# Altium schematic sheet lv_comm.SchDoc(lv_comm)
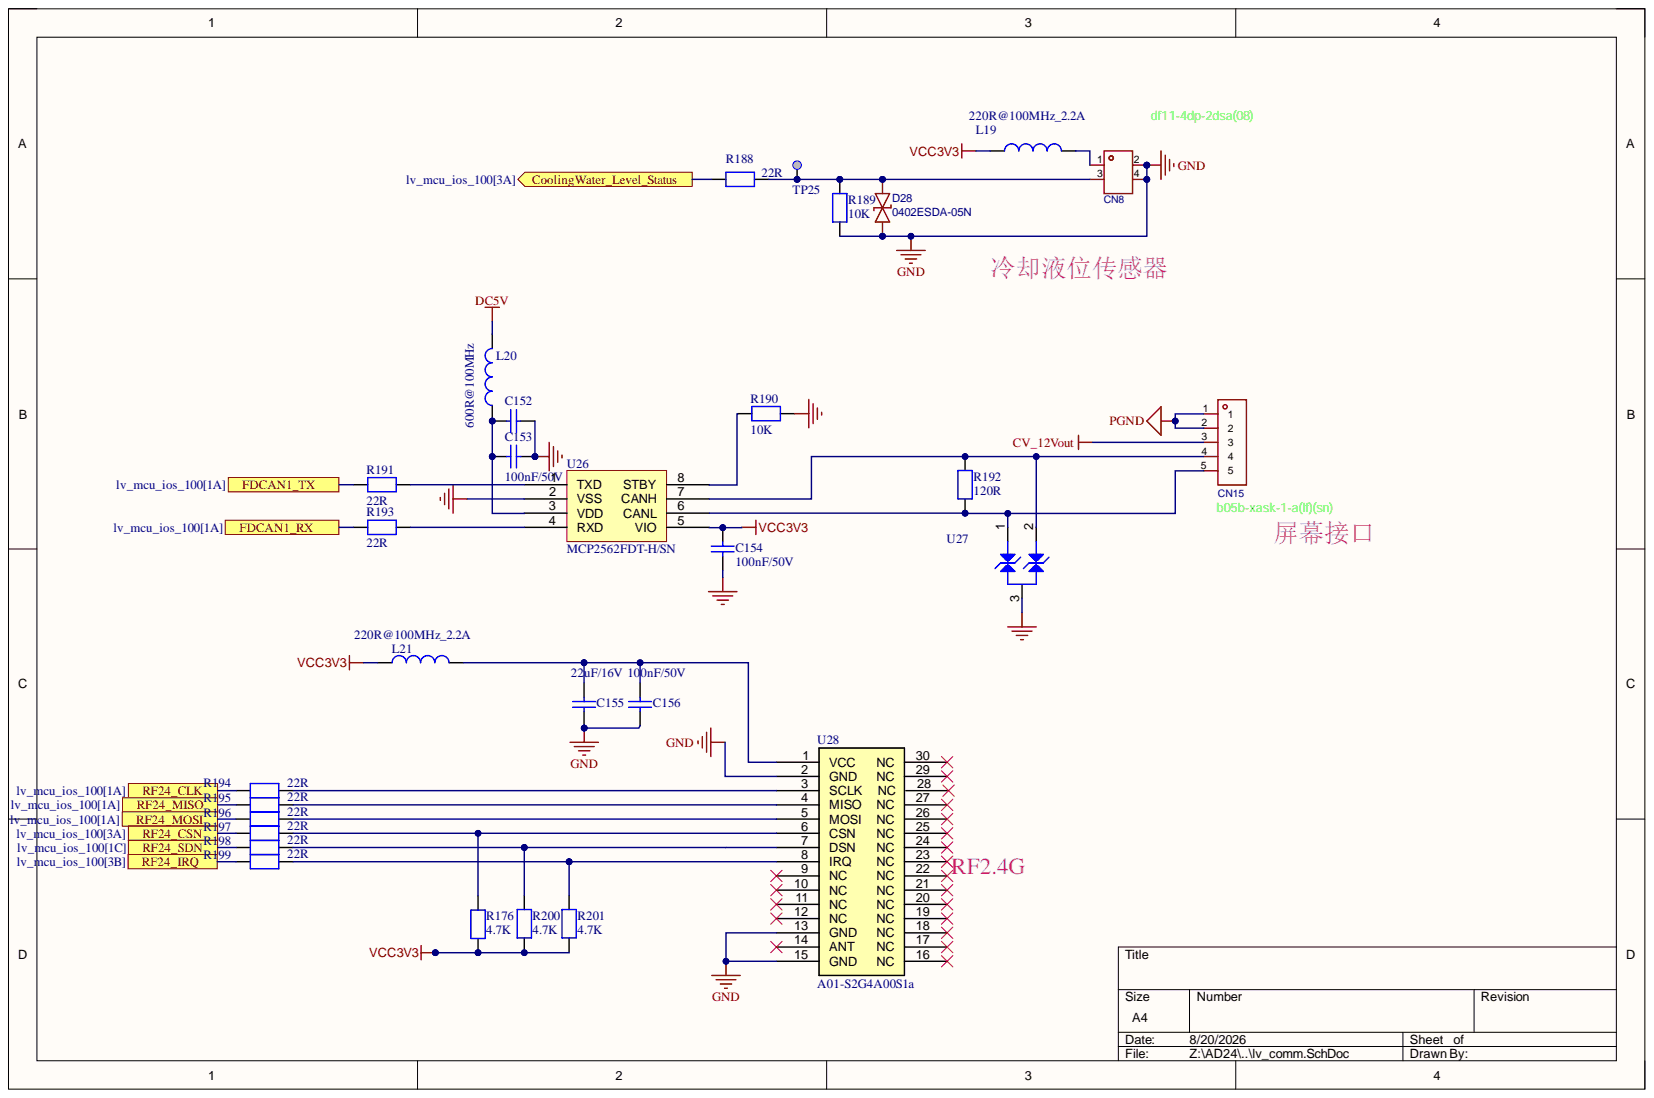
[diagram p0: lv_comm.SchDoc]

## Parts
C152  1uF/50V  [C0603]  (value not drawn)
C153  100nF/50V  [C0402]
C154  100nF/50V  [C0402]
C155  22uF/16V  [C1206]
C156  100nF/50V  [C0402]
CN8  df11-4dp-2dsa(08)  [CONN-TH_DF11-4DP-2DSA-08]
CN15  b05b-xask-1-a(lf)(sn)  [CONN-TH_B05B-XASK-1-A-LF-SN]
D28  0402ESDA-05N  [D0402-BI]
L19  220R@100MHz_2.2A  [L0603]
L20  600R@100MHz  [L0603]
L21  220R@100MHz_2.2A  [L0603]
R176  4.7K  [R0402]
R188  22R  [R0402]
R189  10K  [R0402]
R190  10K  [R0402]
R191  22R  [R0402]
R192  120R  [R0603]
R193  22R  [R0402]
R194  22R  [R0402]
R195  22R  [R0402]
R196  22R  [R0402]
R197  22R  [R0402]
R198  22R  [R0402]
R199  22R  [R0402]
R200  4.7K  [R0402]
R201  4.7K  [R0402]
TP25  Test Point  [TP_SMD_1MM]  (value not drawn)  (no BOM)
U26  MCP2562FD  value MCP2562FDT-H/SN  Manufacturer_Part_Number: MCP2562FDT-H/SN  [ICC_SO8_5X4_P127]
U27  ESDCAN03-2BWY  [DIO_SOT323]  (value not drawn)
U28  A01-S2G4A00S1a  [S24-2020.4.1]

## Ports
CoolingWater_Level_Status, FDCAN1_RX, FDCAN1_TX, RF24_CLK, RF24_CSN, RF24_IRQ, RF24_MISO, RF24_MOSI, RF24_SDN

## Nets
CV_12Vout: CN15.3
DC5V: L20.2
GND: C152.2 C153.2 C154.2 C155.1 C156.2 CN8.2 CN8.4 D28.1 R189.1 R190.2 U26.2(VSS) U27.3 U28.2(GND) U28.13(GND) U28.15(GND)
PGND: CN15.1 CN15.2
VCC3V3: C154.1 L19.2 L21.2 R176.1 R200.1 R201.1 U26.5(VIO)
CoolingWater_Level_Status: R188.1 ; port CoolingWater_Level_Status
FDCAN1_RX: R193.1 ; port FDCAN1_RX
FDCAN1_TX: R191.1 ; port FDCAN1_TX
RF24_CLK: R194.1 ; port RF24_CLK
RF24_CSN: R197.1 ; port RF24_CSN
RF24_IRQ: R199.1 ; port RF24_IRQ
RF24_MISO: R195.1 ; port RF24_MISO
RF24_MOSI: R196.1 ; port RF24_MOSI
RF24_SDN: R198.1 ; port RF24_SDN
NetC152_1: C152.1 C153.1 L20.1 U26.3(VDD)
NetC155_2: C155.2 C156.1 L21.1 U28.1(VCC)
NetCN8_1: CN8.1 L19.1
NetCN8_3: CN8.3 D28.2 R188.2 R189.2 TP25.1
NetCN15_4: CN15.4 R192.1 U26.7(CANH) U27.2
NetCN15_5: CN15.5 R192.2 U26.6(CANL) U27.1
NetR176_2: R176.2 R197.2 U28.6(CSN)
NetR190_1: R190.1 U26.8(STBY)
NetR191_2: R191.2 U26.1(TXD)
NetR193_2: R193.2 U26.4(RXD)
NetR194_2: R194.2 U28.3(SCLK)
NetR195_2: R195.2 U28.4(MISO)
NetR196_2: R196.2 U28.5(MOSI)
NetR198_2: R198.2 R200.2 U28.7(DSN)
NetR199_2: R199.2 R201.2 U28.8(IRQ)

Not connected: U28 9(NC) 10(NC) 11(NC) 12(NC) 14(ANT) 16(NC) 17(NC) 18(NC) 19(NC) 20(NC) 21(NC) 22(NC) 23(NC) 24(NC) 25(NC) 26(NC) 27(NC) 28(NC) 29(NC) 30(NC)

## Library data of the parts
C152 = MCD-00010: 50V, X5R; Manufacturer: YAGEO; Manufacturer_Part_Number: CC0603KRX5R7BB105; Part_Type: Capacitor; Database Table Name: MCD_PARTS$; Initial_Board_Reference: MB1274; Provided_By: Subcon; Component Kind: Standard; ComponentLink1Description: Yageo_Capacitor_X5R-series.pdf; ComponentLink1URL: http://tunmcdsvn.tun.st.com/Component_Datasheet_Library/Trunk/Capacitors/Yageo_Multilayer_capacitor_X5R_series_100pF_to_100uF; ECCN_EU: n/a; ECCN_US: n/a; Internal_Part_Number: MCD-00010; Library Name: MCD_PARTS_database.DbLib; Package: C0603; Status: Released
C153 C154 = MCD-00006: 100nF, 50V, X7R, 10%; Manufacturer: YAGEO; Manufacturer_Part_Number: GRM155R71H104KE14D; Database Table Name: MCD_PARTS$; Component Kind: Standard; ComponentLink1Description: Datasheet; ComponentLink1URL: http://tunmcdsvn.tun.st.com/Component_Datasheet_Library/Trunk/Capacitors/Yageo_Multilayer_Capacitor_X7R_series_100pF_to_22uF; Library Name: MCD_PARTS_database.DbLib
C155 = Capacitor_1: 16V, X5R, 10%; Manufacturer: muRata; Manufacturer_Part_Number: GRM31CR61C226KE15L; Component Kind: Standard; Library Name: HiPin_Library_AD.IntLib
C156 = Capacitor: 50V, 10%, X7R; Internal_Part_Number: MCD-00003; Manufacturer: muRata; Manufacturer_Part_Number: GRM155R71H104KE14D; Value: 100nF; Component Kind: Standard; ComponentLink1Description: Datasheet; ComponentLink1URL: http://tunmcdsvn.tun.st.com/Component_Datasheet_Library/Trunk/Capacitors/Yageo_Capacitor_NP0_16V-to-50V; Library Name: HiPin_Library_AD.IntLib; Package: C0402
CN8 = df11-4dp-2dsa: 插针结构:2x2P;间距:2mm;安装方式:直插;参考系列:-;总PIN数:4P;排数:2;每排PIN数:2;行距:2mm;额定电流:-;工作温度范围:-;; Manufacturer: HRS(广濑); AddInBom: yes; ModelId: 0e73d8cf2edd4592bd87ebe7b4725fde; ModelTransform: 236.22,196.85,0,0,0,0,0,0,-118.11; Number of PINs Per Row: 2; Pins Structure: 2x2P; Pitch: 2mm; Component Kind: Standard; ConvertToPcb: yes; Current Rating (Max): -; Datasheet: https://atta.szlcsc.com/upload/public/pdf/source/20200402/C506665_F433E61D9F10FCB2CA56C5EB49D0F0CF.pdf; FootprintName: CONN-TH_DF11-4DP-2DSA-08; JLCPCB Part Class: Extended Part; LCSC Part Name: 2x2P 间距:2mm 直插; Library Name: HiPin_Library_AD.IntLib; ManufacturerPart: DF11-4DP-2DSA(08); ModelName: CONN-TH_DF11-4DP-2DSA-08; Mounting Style: 直插; Number of Pins: 4P; Number of Rows: 2; Operating Temperature Range: -; Reference Series: -; Row Spacing: 2mm; Supplier: LCSC; Supplier Footprint: 插件,P=2mm; SupplierPart: C506665; Symbol: DF11-4DP-2DSA(08)
CN15 = b05b-xask-1-a(lf)(sn): 插针结构:1x5P;间距:2.5mm;安装方式:直插;参考系列:XA;总PIN数:5P;排数:1;每排PIN数:5;行距:-;额定电流:3A;触头材质:铜合金;触头镀层:锡;工作温度:-25℃~+85℃;; Manufacturer: JST; AddInBom: yes; Current Rating: 3A; Flame Retardant Rating: UL94V-0; ModelId: e0ab7f3b215b4606a0772da92fe8ba27; ModelTransform: 590.58937,248.031,0,0,0,0,0,0,-118.11; Number of PINs Per Row: 5; Pins Structure: 1x5P; Pitch: 2.5mm; Plastic Material: 玻纤增强PA66; Reference Series: XA; Supplementary Features: 打K脚；带柱; Voltage Rating: 250V; X-Length of Bottom Edge on Board (Spacing Line): 15mm; Y-Width of Bottom Edge on Board: 6.4mm; Z-Height of the Board: 8.8mm; Color: 白色; Component Kind: Standard; Contact Material: 铜合金; Contact Plating: 锡; ConvertToPcb: yes; Datasheet: https://item.szlcsc.com/datasheet/B05B-XASK-1-A(LF)(SN)/502110.html; FootprintName: CONN-TH_B05B-XASK-1-A-LF-SN; JLCPCB Part Class: Extended Part; LCSC Part Name: 1x5P 间距:2.5mm 直插 系列:XA; Library Name: HiPin_Library_AD.IntLib; ManufacturerPart: B05B-XASK-1-A(LF)(SN); ModelName: CONN-TH_B05B-XASK-1-A-LF-SN; Mounting Type: 直插; Number of Pins: 5P; Number of Rows: 1; Operating Temperature: -25℃~+85℃; Row Spacing: -; Supplier: LCSC; Supplier Footprint: 插件,P=2.5mm; SupplierPart: C491708; Symbol: B05B-XASK-1-A(LF)(SN)
D28 = 0402ESDA-05N: 双向ESD，反向截止电压5V; BOM_Manufacturer: Bourne Semicon (Shenzhen); Contributor: LCSC; BOM_JLCPCB Part Class: Extended Part; BOM_Manufacturer Part: 0402ESDA-05N; BOM_Supplier: LCSC; BOM_Supplier Part: C316049; Component Kind: Standard; Library Name: HiPin_Library_AD.IntLib; spicePre: D; spiceSymbolName: 0402ESDA-05N
L19 L21 = MCD-00047: SMD Chip ferrite bead, 220R@100MHz +/-25%,2.2A, 50mohm; Manufacturer: Sunlord; Manufacturer_Part_Number: UPZ1608U221-2R2TF; Database Table Name: MCD_PARTS$; Component Kind: Standard; ComponentLink1Description: Datasheet; ComponentLink1URL: http://tunmcdsvn.tun.st.com/Component_Datasheet_Library/Trunk/Inductors/TaiTech_Bead_FCM1608_series; Internal_Part_Number: MCD-00047; Library Name: MCD_PARTS_database.DbLib
L20 = MCD-00047: +/-25%, 350mA, 500mohm DC; Manufacturer_Part_Number: FCM1608KF-601T03; Database Table Name: MCD_PARTS$; Component Kind: Standard; ComponentLink1Description: Datasheet; ComponentLink1URL: http://tunmcdsvn.tun.st.com/Component_Datasheet_Library/Trunk/Inductors/TaiTech_Bead_FCM1608_series; Library Name: MCD_PARTS_database.DbLib
R176 R188 R191-R201 = RES: 1%; Value: 10K; Component Kind: Standard; Library Name: HiPin_Library_AD.IntLib
R189 R190 = RES: 1%; Component Kind: Standard; Library Name: HiPin_Library_AD.IntLib; Value: 10K
TP25 = MCD-00074: Test Point 1mm; Part_Type: Mechanical; Database Table Name: MCD_PARTS$; ComponentLink1Description: Datasheet; ComponentLink1URL: http://na; ECCN_EU: n/a; ECCN_US: n/a; Internal_Part_Number: MCD-00074; Library Name: MCD_PARTS_database.DbLib; Manufacturer: n/a; Manufacturer_Part_Number: n/a; Provided_By: n/a; Status: Released
U26 = MCD-00129: High-Speed CAN Flexible Data Rate Transceiver; Manufacturer: MICROCHIP; Part_Type: IC; Database Table Name: MCD_PARTS$; Initial_Board_Reference: MB1262; Item Type: Item; Path: ws/MCD_ApplicationBoards/Lists/MCDPARTSdatabase; Provided_By: SUBCO; Component Kind: Standard; ComponentLink1Description: Datasheet; ComponentLink1URL: http://tunmcdsvn.tun.st.com/Component_Datasheet_Library/Trunk/IC/Microchip_CAN Transceiver_MCP2562FD; Internal_Part_Number: MCD-00129; Library Name: MCD_PARTS_database.DbLib; Status: Released
U27 = MCD-01228: 双线TVS,反向截止电压24V; Manufacturer: STMICROELECTRONICS; Database Table Name: MCD_PARTS$; Component Kind: Standard; Library Name: MCD_PARTS_database.DbLib; Manufacturer_Part_Number: ESDCAN03-2BWY
U28 = A01-S2G4A00S1a: RF2.4G; Component Kind: Standard; Library Name: HiPin_Library_AD.IntLib
